# Altium schematic sheet usb_conn.SchDoc
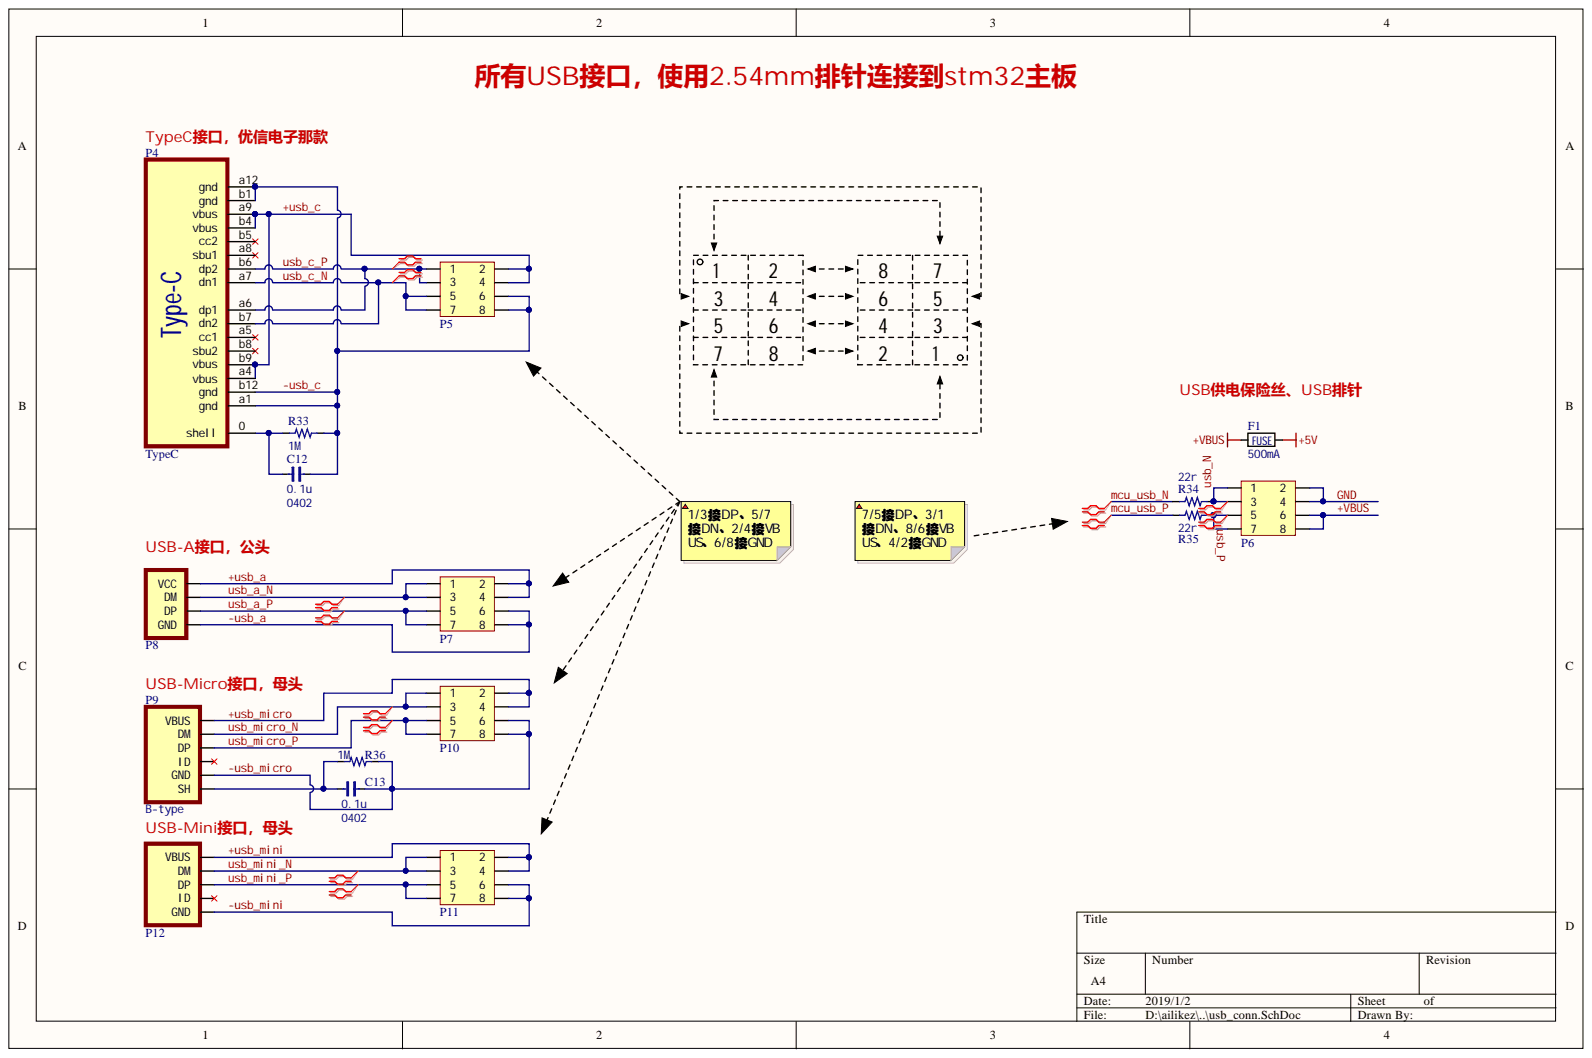
[diagram p0: usb_conn.SchDoc]

## Parts
C12  value 0.1u  Type: 0402  [CAP_0402]  (hidden comment: C0402)
C13  value 0.1u  Type: 0402  [CAP_0402]  (hidden comment: C0402)
F1  500mA  [PPTC_0603]
P4  TypeC  [USB_TypeC]
P5  HDR2x4_2.54  [HDR2X4_2.54_180HF]  (value not drawn)
P6  HDR2x4_2.54  [HDR2X4_2.54_180H]  (value not drawn)
P7  HDR2x4_2.54  [HDR2X4_2.54_180HF]  (value not drawn)
P8  USB_Plug_TypeA  [USB_Plug_TypeA]  (value not drawn)
P9  Type: B-type  [USB_Micro-AB]  (hidden comment: MicroUSB)
P10  HDR2x4_2.54  [HDR2X4_2.54_180HF]  (value not drawn)
P11  HDR2x4_2.54  [HDR2X4_2.54_180HF]  (value not drawn)
P12  MiniUSB  [USB_Mini-B]  (value not drawn)
R33  1M  [RES_0402]
R34  22r  [RES_0402]
R35  22r  [RES_0402]
R36  1M  [RES_0402]

## Nets
+5V: F1.2
+usb_a: P7.2 P7.4 P8.1(VCC)
+usb_c: P4.a4(vbus) P4.a9(vbus) P4.b4(vbus) P4.b9(vbus) P5.2 P5.4
+usb_micro: P9.1(VBUS) P10.2 P10.4
+usb_mini: P11.2 P11.4 P12.1(VBUS)
+VBUS: F1.1 P6.6 P6.8
-usb_a: P7.6 P7.8 P8.4(GND)
-usb_c: C12.2 P4.a1(gnd) P4.a12(gnd) P4.b1(gnd) P4.b12(gnd) P5.6 P5.8 R33.2
-usb_micro: C13.2 P9.5(GND) P10.6 P10.8 R36.2
-usb_mini: P11.6 P11.8 P12.5(GND)
GND: P6.2 P6.4
mcu_usb_N: R34.1
mcu_usb_P: R35.1
usb_a_N: P7.1 P7.3 P8.2(DM)
usb_a_P: P7.5 P7.7 P8.3(DP)
usb_c_N: P4.a7(dn1) P4.b7(dn2) P5.5 P5.7
usb_c_P: P4.a6(dp1) P4.b6(dp2) P5.1 P5.3
usb_micro_N: P9.2(DM) P10.1 P10.3
usb_micro_P: P9.3(DP) P10.5 P10.7
usb_mini_N: P11.1 P11.3 P12.2(DM)
usb_mini_P: P11.5 P11.7 P12.3(DP)
usb_N: P6.1 P6.3 R34.2
usb_P: P6.5 P6.7 R35.2
NetC12_1: C12.1 P4.0(shell) R33.1
NetC13_1: C13.1 P9.0(SH) R36.1

Not connected: P4 a5(cc1) a8(sbu1) b5(cc2) b8(sbu2); P9 4(ID); P12 4(ID)

## Library data of the parts
C12 C13 = Cap_0402: Capacitor; Specification: X7R 50V; Component Kind: Standard; Library Name: adlib.IntLib
F1 = Fuse: resettable fuse; Component Kind: Standard; Library Name: adlib.IntLib
P4 = USB_TypeC: USB type-c cable; Component Kind: Standard; Library Name: adlib.IntLib
P5-P7 P10 P11 = HDR2x4_2.54: Header; Component Kind: Standard; Library Name: adlib.IntLib
P8 = USB_Plug_TypeA: USB; Component Kind: Standard; Library Name: adlib.IntLib
P9 = USB_Micro: USB; Component Kind: Standard; Library Name: adlib.IntLib
P12 = USB_Mini: USB; Component Kind: Standard; Library Name: adlib.IntLib
R33-R36 = Res: SMT Resistor; Component Kind: Standard; Library Name: adlib.IntLib
  R33 R36: Value: 1M
  R34 R35: Value: 22r
